# Altium schematic sheet Schematic Prints("All Documents",Physical) (continued)

## Library data of the parts
C1 C91 C93 CD0a CD0b CD0c CD0d CD0e CD0f CD0g CD0h CD0i CD0j CD0k CD20 CD31 CD32 = 100NF_0603_50V_X7R: 128-8255    Capacitor MLC-X7R_0603_100NF_10%_50V; C0603C104K5RAC; KEMET; RoHS=Yes; Signature_Author: Zagata; Value: 100nF; Category: Capacitors; Component Kind: Standard; Library Name: CAP0603_X7R.SchLib; Simulation: CAP; Supplier 1: Farnell; Supplier Part Number 1: 1288255; Signature_Date_Created: 2013-08-27; Signature_Date_LastModified: 2013-08-27
C90 C92 CD0 CD30 = 10UF_1206_25V_X7R: 209-4044    C_MLC-X7R_1206_10UF_10%_25V; 12063C106KAT2A; AVX; RoHS=Yes; Component Kind: Standard; Library Name: CAP1206_X7R.SchLib; Simulation: CAP; Supplier 1: Farnell; Supplier Part Number 1: 2094044
CF1-CF4 = 22PF_0603_50V_NP0: 141-4622    Capacitor MLC-NPO_0603_22PF_5%_50V; C0603C220J5GAC; KEMET; RoHS=Yes; Manufacturer Part Number: C0603C220J5GACTU; Capacitance: 22pF; Dielectric: C0G; Dielectric Material: Ceramic; Temperature Coefficient: 30ppm/°C; Tolerance: 5%; Voltage: 50V; Case Code (Imperial): 0603; Case Code (Metric): 1608; Case/Package: 0603; Component Kind: Standard; ComponentLink1Description: https://datasheet.ciiva.com/18192/getdatasheetpartid-385505-18192854.pdf?src-supplier=Verical; ComponentLink1URL: https://datasheet.ciiva.com/18192/getdatasheetpartid-385505-18192854.pdf?src-supplier=Verical; ComponentLink2Description: https://datasheet.ciiva.com/14586/70095383-14586058.pdf?src-supplier=Allied+Electronics; ComponentLink2URL: https://datasheet.ciiva.com/14586/70095383-14586058.pdf?src-supplier=Allied+Electronics; ComponentLink3Description: https://datasheet.ciiva.com/7824/f9080b-0402-series-c0g-7824012.pdf?src-supplier=Element14; ComponentLink3URL: https://datasheet.ciiva.com/7824/f9080b-0402-series-c0g-7824012.pdf?src-supplier=Element14; ComponentLink4Description: https://datasheet.ciiva.com/14599/70095383-14599853.pdf?src-supplier=Allied+Electronics; ComponentLink4URL: https://datasheet.ciiva.com/14599/70095383-14599853.pdf?src-supplier=Allied+Electronics; Contact Material: Tin; Depth: 0.8mm; Height: 0.8mm; Lead Free: Lead Free; Length: 1.6mm; Library Name: CAP0603_NPO.SchLib; Manufacturer: KEMET; Max Operating Temperature: 125°C; Min Operating Temperature: -55°C; Mount: Surface Mount,PCB; Number of Pins: 2; Package Quantity: 1; Packaging: Tape and Reel; Pricing 2: 1=0.17000, 10=0.12100, 100=0.05670, 500=0.03976, 1000=0.03291 (USD); Radiation Hardening: No; REACH SVHC: No SVHC; RoHS: Yes; Stock 1: 116489; Stock 2: 1090446; Supplier 1: Farnell; Supplier 2: Digi-Key; Supplier Part Number 1: 1414622; Supplier Part Number 2: 399-1053-1-ND; Termination: SMD/SMT; Thickness: 0.034inch; Voltage Rating: 50V; Voltage Rating (DC): 50V; Weight: 7.1E-05oz; Width: 0.8mm
CON_USB = USBmicro-B_2173157-3: 253-2426    2173157-3; Micro USB-B, RCP 5 POS 0.65mm Solder RA SMD 5 Terminal 1 Port T/R; TE; Manufacturer: TE Connectivity; Centerline_Pitch: .65 mm[.025 in]; Circuit Application: Power; Connector System: Cable-to-Board; Connector Type: Receptacle; Contact Current Rating: 1.8A; Gender: Female; Number of Ports: 1; Number of Positions: 5; Pitch: 0.025inch; Plating: Nickel,Tin; Product_Type: Connector; Voltage Rating: 30V; Component Kind: Standard; ComponentLink1Description: https://www.te.com/commerce/DocumentDelivery/DDEController?Action=srchrtrv&DocNm=2173157&DocType=Customer+Drawing&DocLang=English&src-supplier=Digi-Key; ComponentLink1URL: https://www.te.com/commerce/DocumentDelivery/DDEController?Action=srchrtrv&DocNm=2173157&DocType=Customer+Drawing&DocLang=English&src-supplier=Digi-Key; ComponentLink2Description: http://www.te.com/catalog/pn/en/2173157-3?RQPN=2173157-3&src-supplier=Avnet; ComponentLink2URL: http://www.te.com/catalog/pn/en/2173157-3?RQPN=2173157-3&src-supplier=Avnet; ComponentLink3Description: http://www.te.com/commerce/DocumentDelivery/DDEController?Action=srchrtrv&DocNm=2173157&DocType=Customer+Drawing&DocLang=English&src-supplier=Digi-Key; ComponentLink3URL: http://www.te.com/commerce/DocumentDelivery/DDEController?Action=srchrtrv&DocNm=2173157&DocType=Customer+Drawing&DocLang=English&src-supplier=Digi-Key; Contact Material: Copper Alloy; Contact Plating: Gold; Current Rating: 1.8A; Digi-Key_Part_Number: A124356CT-ND; Digi-Key_Purchase_URL: https://www.digikey.com/product-detail/en/te-connectivity-amp-connectors/2173157-3/A124356CT-ND/6026114?utm_source=snapeda&utm_medium=aggregator&utm_campaign=symbol; ELV: Compliant; EU_RoHS_Compliance: Compliant; Housing Color: Black; Housing Material: Thermoplastic; Lead Free: Lead Free; Length: 8.2mm; Library Name: CONNECTOR_USB.SchLib; Manufacturer Part Number: 2173157-3; Max Operating Temperature: 85°C; MF: TE Connectivity; Min Operating Temperature: -30°C; Mount: Surface Mount; MP: 2173157-3; Number_of_Positions: 5; Orientation: Right Angle; Package: None; Package Quantity: 1000; Packaging: Tape and Reel; Pricing 2: 1=3.39000, 10=3.25400, 25=2.98280, 50=2.84700, 100=2.71150, 250=2.37256, 500=2.30478 (USD); RoHS: Yes; SnapEDA_Link: https://www.snapeda.com/parts/2173157-3/TE%20Connectivity%20AMP%20Connectors/view-part/?ref=snap; Stock 1: 1167; Stock 2: 4317; Supplier 1: Farnell; Supplier 2: Digi-Key; Supplier Part Number 1: 2532426; Supplier Part Number 2: A124356CT-ND; TE_purchase_URL: https://www.te.com/usa-en/product-2173157-3.html?te_bu=Cor&te_type=disp&te_campaign=seda_glo_cor-seda-global-disp-prtnr-fy19-seda-model-bom-cta_sma-317_1&elqCampaignId=32493; Termination: SMD/SMT
CX1 CX2 = 22PF_0603_50V_NP0: 141-4622    Capacitor MLC-NPO_0603_22PF_5%_50V; C0603C220J5GAC; KEMET; RoHS=Yes; Capacitance: 22pF; Dielectric: C0G; Dielectric Material: Ceramic; Temperature Coefficient: 30ppm/°C; Tolerance: 5%; Voltage: 50V; Case Code (Imperial): 0603; Case Code (Metric): 1608; Case/Package: 0603; Component Kind: Standard; ComponentLink1Description: https://datasheet.ciiva.com/18192/getdatasheetpartid-385505-18192854.pdf?src-supplier=Verical; ComponentLink1URL: https://datasheet.ciiva.com/18192/getdatasheetpartid-385505-18192854.pdf?src-supplier=Verical; ComponentLink2Description: https://datasheet.ciiva.com/14586/70095383-14586058.pdf?src-supplier=Allied+Electronics; ComponentLink2URL: https://datasheet.ciiva.com/14586/70095383-14586058.pdf?src-supplier=Allied+Electronics; ComponentLink3Description: https://datasheet.ciiva.com/7824/f9080b-0402-series-c0g-7824012.pdf?src-supplier=Element14; ComponentLink3URL: https://datasheet.ciiva.com/7824/f9080b-0402-series-c0g-7824012.pdf?src-supplier=Element14; ComponentLink4Description: https://datasheet.ciiva.com/14599/70095383-14599853.pdf?src-supplier=Allied+Electronics; ComponentLink4URL: https://datasheet.ciiva.com/14599/70095383-14599853.pdf?src-supplier=Allied+Electronics; Contact Material: Tin; Depth: 0.8mm; Height: 0.8mm; Lead Free: Lead Free; Length: 1.6mm; Library Name: CAP0603_NPO.SchLib; Manufacturer: KEMET; Max Operating Temperature: 125°C; Min Operating Temperature: -55°C; Mount: Surface Mount,PCB; Number of Pins: 2; Package Quantity: 1; Packaging: Tape and Reel; Pricing 2: 1=0.17000, 10=0.12100, 100=0.05670, 500=0.03976, 1000=0.03291 (USD); Radiation Hardening: No; REACH SVHC: No SVHC; RoHS: Yes; Stock 1: 116489; Stock 2: 1090446; Supplier 1: Farnell; Supplier 2: Digi-Key; Supplier Part Number 1: 1414622; Supplier Part Number 2: 399-1053-1-ND; Termination: SMD/SMT; Thickness: 0.034inch; Voltage Rating: 50V; Voltage Rating (DC): 50V; Weight: 7.1E-05oz; Width: 0.8mm
D1 = HSMD-C191: 105-8359    LED DIODE ORANGE 20mA, 0603; HSMD-C191; AVAGO TECHNOLOGIES; RoHS=Yes; Component Kind: Standard; Library Name: DIODES_LED_SMD.SchLib; Simulation: LED2; Supplier 1: Farnell; Supplier Part Number 1: 1058359
D2 = HSMG-C191: 855-4617    LED DIODE GREEN 20mA, 0603; HSMG-C191; AVAGO TECHNOLOGIES; RoHS=Yes; Component Kind: Standard; Library Name: DIODES_LED_SMD.SchLib; Simulation: LED2; Supplier 1: Farnell; Supplier Part Number 1: 8554617
D9 = MBRS140T3G: 955-7237    DIODE SCHOTTKY 40V/1A, DO214AA; MBRS140T3G; ONSEMI; RoHS=Yes; Component Kind: Standard; Library Name: DIODES_SCHOTTKY.SchLib; Supplier 1: Farnell; Supplier 2: Digi-Key; Supplier Part Number 1: 9557237; Supplier Part Number 2: MBRS140T3GOSCT-ND
F1 F31 F32 = FILTER_ NFE31PT222Z1E9L: 952-8172     NFE31PT222Z1E9L ; FILTER, POWER LINE, 2200pF, 25V, 6A; 1206; Murata; 0_Signature: Status: APROVED, Author: I. Zagata, Created: 2019-09-19, Last Modified: 2019-09-19; 1_Category: Inductors; 2_Typ: Filter, EMI; Dielectric Material: Ceramic; ESD Protection: No; Insulation Resistance: 1GR; Number of Channels: 1; Tolerance: 50%; Case Code (Imperial): 1206; Case Code (Metric): 3216; Case/Package: 1206; Component Kind: Standard; ComponentLink1Description: https://datasheet.ciiva.com/34302/1871107-34302922.pdf?src-supplier=Jameco; ComponentLink1URL: https://datasheet.ciiva.com/34302/1871107-34302922.pdf?src-supplier=Jameco; ComponentLink2Description: https://datasheet.ciiva.com/11795/0900766b80032ea2-11795504.pdf?src-supplier=RSComponents; ComponentLink2URL: https://datasheet.ciiva.com/11795/0900766b80032ea2-11795504.pdf?src-supplier=RSComponents; ComponentLink3Description: https://datasheet.ciiva.com/22711/1756155-22711313.pdf?src-supplier=Element14; ComponentLink3URL: https://datasheet.ciiva.com/22711/1756155-22711313.pdf?src-supplier=Element14; ComponentLink4Description: https://datasheet.ciiva.com/26793/nfe31pt222z1e9l-26793392.pdf?src-supplier=IIIC; ComponentLink4URL: https://datasheet.ciiva.com/26793/nfe31pt222z1e9l-26793392.pdf?src-supplier=IIIC; ComponentLink5Description: https://datasheet.ciiva.com/1469/1756155-1469035.pdf?src-supplier=Element14; ComponentLink5URL: https://datasheet.ciiva.com/1469/1756155-1469035.pdf?src-supplier=Element14; ComponentLink6Description: https://datasheet.ciiva.com/1469/10397-1469036.pdf?src-supplier=Element14; ComponentLink6URL: https://datasheet.ciiva.com/1469/10397-1469036.pdf?src-supplier=Element14; ComponentLink7Description: https://datasheet.ciiva.com/1016/l0112s0113nfe31p-1016430.pdf?src-supplier=Digi-Key; ComponentLink7URL: https://datasheet.ciiva.com/1016/l0112s0113nfe31p-1016430.pdf?src-supplier=Digi-Key; ComponentLink8Description: https://datasheet.ciiva.com/16387/l0112s0113nfe31p-16387318.pdf?src-supplier=Future+Electronics; ComponentLink8URL: https://datasheet.ciiva.com/16387/l0112s0113nfe31p-16387318.pdf?src-supplier=Future+Electronics; ComponentLink9Description: https://datasheet.ciiva.com/1016/l0112s0113nfe31p-1016428.pdf?src-supplier=Digi-Key; ComponentLink9URL: https://datasheet.ciiva.com/1016/l0112s0113nfe31p-1016428.pdf?src-supplier=Digi-Key; ComponentLink10Description: https://datasheet.ciiva.com/1016/l0112s0113nfe31p-1016429.pdf?src-supplier=Digi-Key; ComponentLink10URL: https://datasheet.ciiva.com/1016/l0112s0113nfe31p-1016429.pdf?src-supplier=Digi-Key; Current: 6A; Current Rating: 6A; Depth: 1.6mm; Height: 1.6mm; Lead Free: Lead Free; Length: 3.2mm; Library Name: INDUCTORS_SMD.SchLib; Manufacturer: Murata; Manufacturer Part Number: NFE31PT222Z1E9L; Max Current Rating: 6A; Max Operating Temperature: 85°C; Max Voltage Rating (DC): 25V; Min Operating Temperature: -40°C; Mount: Surface Mount,PCB; Number of Pins: 2; Package Quantity: 1; Packaging: Tape and Reel; Radiation Hardening: No; REACH SVHC: No SVHC; RoHS: Yes; Stock 1: 41431; Supplier 1: Farnell; Supplier Part Number 1: 9528172; Termination: Flat; Voltage Rating: 25V; Voltage Rating (DC): 25V; Width: 1.6mm
R1-R21 = 100R_1206_0.063W_5%: 923-4454    RESISTOR ARRAY 100R_1206_0.063W_5%; 235003510101; PHYCOMP; RoHS=Yes; Component Kind: Standard; Simulation: RESISTOR; Supplier 1: Farnell; Supplier Part Number 1: 9234454; Library Name: RESISTOR_PACK_ARV.SchLib
R01 R91 RK1 RK2 = 10K_0603_0.1W_5%: 923-3504    RESISTOR MF_0603_10KR_0.063W_5%_50V; 232270260103; PHYCOMP; RoHS=Yes; Component Kind: Standard; Library Name: Resistor0603_0.063W.SchLib; Simulation: RESISTOR; Supplier 1: Farnell; Supplier Part Number 1: 9233504
R02 = 2.2K_1206_0.063W_5%: 923-4535    RESISTOR ARRAY 2K2_1206_0.063W_5%; 235003510222; PHYCOMP; RoHS=Yes (repl. for 196-794); Component Kind: Standard; Simulation: RESISTOR; Library Name: RESISTOR_PACK_ARV.SchLib
R03 RL1 RL2 = 470R_0603_0.1W_5%: 923-3334    RESISTOR MF_0603_470R_0.1W_5%_50V; RC0603JR-07470RL; YAGEO; RoHS=Yes; Component Kind: Standard; Library Name: Resistor0603_0.063W.SchLib; Simulation: RESISTOR
R31 R32 = 2.0K_0603_0.063W_1%: 933-0763   RESISTOR MF_0603_2.0KR_0.063W_1%_50V;  MC0063W060312K; MULTICOMP; Component Kind: Standard; Library Name: Resistor0603_0.063W.SchLib; Simulation: RESISTOR
SW1 = SWDIP-2-SMD_MULTICOMP: 160-5473    MCDHN-02F-V; SWITCH, HALF-PITCH SMD SO, 2 ways; MULTICOMP; Component Kind: Standard; Library Name: MISC.SchLib; Supplier 1: Farnell; Supplier Part Number 1: 1605473
TP = TPAD: xxx-xxxx    TEST PAD - NO COMPONENT TO SOLDER; Signature_Author: Zagata; Category: Misc; Library Name: MISC.SchLib; Signature_Date_Created: 2013-08-27; Signature_Date_LastModified: 2013-08-27
U0 = PIC32MZ1024EFH100-E/PF_ONMCU-V2: DK:PIC32MZ1024EFH100-E/PF-ND    PIC32MZ1024EFH100-E/PF; MIPS32® M-Class Automotive, AEC-Q100, PIC® 32MZ Microcontroller IC 32-Bit 180MHz 1MB (1M x 8) FLASH; TQFP100 (14x14); Microchip; 0_Signature: Status: APROVED, Author: I. Zagata, Created: 2019-08-23, Last Modified: 2019-08-23; 1_Category: ICs; Case/Package: TQFP; Component Kind: Standard; Library Name: IC_MCUs.SchLib; Manufacturer: Microchip; Pricing 1: 1=12.07000, 25=11.06240, 100=10.02190 (USD); RoHS: Yes; Stock 1: 263; Supplier 1: Digi-Key; Supplier Part Number 1: PIC32MZ1024EFH100-E/PF-ND
U9 = MC33375ST-3.3T3G: 115-0444    REGULATOR 3.3V, 0.3A; MC33375ST-3.3T3G; ON SEMI; RoHS=Yes; Component Kind: Standard; Supplier 1: Farnell; Supplier 2: Digi-Key; Supplier Part Number 1: 2296053; Supplier Part Number 2: MC33375ST-3.3T3GOSCT-ND; Library Name: IC_VOLT_REG.SchLib
U20 = 7S14P5X_SC70: 146-7334    NC7S14P5X; Single Schmitt-Trigger Inverter; FAIRCHILD; RoHS=Yes; Repl for 126-2608; Manufacturer: ON Semiconductor / Fairchild; High Level Output Current: -2.6mA; Logic Function: Buffer,Inverter,Schmitt Trigger; Low Level Output Current: 2.6mA; Max Supply Voltage: 6V; Min Supply Voltage: 2V; Number of Circuits: 1; Propagation Delay: 17ns; Quiescent Current: 1uA; Schmitt Trigger Input: Yes; Turn-On Delay Time: 125ns; Case/Package: SC; Component Kind: Standard; ComponentLink1Description: https://datasheet.ciiva.com/4826/nc7s14-115813-4826946.pdf?src-supplier=Mouser; ComponentLink1URL: https://datasheet.ciiva.com/4826/nc7s14-115813-4826946.pdf?src-supplier=Mouser; ComponentLink2Description: https://datasheet.ciiva.com/34301/873784-34301634.pdf?src-supplier=Jameco; ComponentLink2URL: https://datasheet.ciiva.com/34301/873784-34301634.pdf?src-supplier=Jameco; ComponentLink3Description: https://datasheet.ciiva.com/15089/getdatasheetpartid-69776-15089145.pdf?src-supplier=Verical; ComponentLink3URL: https://datasheet.ciiva.com/15089/getdatasheetpartid-69776-15089145.pdf?src-supplier=Verical; ComponentLink4Description: https://datasheet.ciiva.com/25628/0900766b810e259d-25628669.pdf?src-supplier=RSComponents; ComponentLink4URL: https://datasheet.ciiva.com/25628/0900766b810e259d-25628669.pdf?src-supplier=RSComponents; ComponentLink5Description: https://datasheet.ciiva.com/1861/nc7s14-1861941.pdf?src-supplier=Digi-Key; ComponentLink5URL: https://datasheet.ciiva.com/1861/nc7s14-1861941.pdf?src-supplier=Digi-Key; ComponentLink6Description: https://datasheet.ciiva.com/8248/nc7s14-8248821.pdf?src-supplier=Avnet; ComponentLink6URL: https://datasheet.ciiva.com/8248/nc7s14-8248821.pdf?src-supplier=Avnet; ComponentLink7Description: https://datasheet.ciiva.com/1861/nc7s14-1861942.pdf?src-supplier=Digi-Key; ComponentLink7URL: https://datasheet.ciiva.com/1861/nc7s14-1861942.pdf?src-supplier=Digi-Key; ComponentLink8Description: https://datasheet.ciiva.com/1861/nc7s14-1861943.pdf?src-supplier=Digi-Key; ComponentLink8URL: https://datasheet.ciiva.com/1861/nc7s14-1861943.pdf?src-supplier=Digi-Key; ComponentLink9Description: https://datasheet.ciiva.com/16989/nc7s14-16989591.pdf?src-supplier=Future+Electronics; ComponentLink9URL: https://datasheet.ciiva.com/16989/nc7s14-16989591.pdf?src-supplier=Future+Electronics; ComponentLink10Description: https://datasheet.ciiva.com/26242/nc7s14-26242158.pdf?src-supplier=Avnet+Europe; ComponentLink10URL: https://datasheet.ciiva.com/26242/nc7s14-26242158.pdf?src-supplier=Avnet+Europe; ComponentLink11Description: https://datasheet.ciiva.com/48704/001253334-da-01-en-ic-inverter-hs-sch-nc7s14p5x-sc-70-5-fsc-48704727.pdf?src-supplier=Conrad; ComponentLink11URL: https://datasheet.ciiva.com/48704/001253334-da-01-en-ic-inverter-hs-sch-nc7s14p5x-sc-70-5-fsc-48704727.pdf?src-supplier=Conrad; Contact Plating: Tin,Matte; Height: 0.9mm; Lead Free: Lead Free; Length: 2mm; Library Name: IC_74xx.SchLib; Max Operating Temperature: 85°C; Min Operating Temperature: -40°C; Mount: Surface Mount; Number of Elements: 1; Number of Gates: 1; Number of Inputs: 1; Number of Pins: 5; Output Current: 2.6mA; Package Quantity: 3000; Packaging: Tape and Reel; Pricing 2: 1=0.33000, 10=0.24600, 100=0.13950, 500=0.09240, 1000=0.07084 (USD); Radiation Hardening: No; REACH SVHC: No SVHC; RoHS: Yes; Stock 1: 19135; Stock 2: 8563; Supplier 1: Farnell; Supplier 2: Digi-Key; Supplier Part Number 1: 1467334; Supplier Part Number 2: NC7S14P5XCT-ND; Weight: 23g; Width: 1.25mm
U31 U32 = AD5641BKSZ-500RL7: 237-6822    AD5641BKSZ; DAC, 14bit, SPI, 2.7 .. 5.5V; SC70-6; AD; Manufacturer: Analog Devices; 0_Signature: Status: APROVED, Author: I. Zagata, Created: 2019-08-29, Last Modified: 2019-08-29; 1_Category: ICs; Conversion Rate: 1.7MS/s; Differential Output: No; Integral Nonlinearity (INL): 4LSB; Interface: SPI,Serial; Max Power Dissipation: 550uW; Min Input Voltage: 2.7V; Nominal Supply Current: 75uA; Number of Bits: 14; Number of Channels: 1; Output Type: Voltage; Polarity: Unipolar,Bipolar; Power Dissipation: 5.5mW; Resolution: 14b; Settling Time: 6us; Slew Rate: 0.5V/µs; Case/Package: SC; Component Kind: Standard; ComponentLink1Description: https://datasheet.ciiva.com/6665/ad5641-6665824.pdf?src-supplier=Digi-Key; ComponentLink1URL: https://datasheet.ciiva.com/6665/ad5641-6665824.pdf?src-supplier=Digi-Key; ComponentLink2Description: https://datasheet.ciiva.com/1360/ad5641-1360625.pdf?src-supplier=Digi-Key; ComponentLink2URL: https://datasheet.ciiva.com/1360/ad5641-1360625.pdf?src-supplier=Digi-Key; ComponentLink3Description: https://datasheet.ciiva.com/8191/ad5641-8191978.pdf?src-supplier=Avnet; ComponentLink3URL: https://datasheet.ciiva.com/8191/ad5641-8191978.pdf?src-supplier=Avnet; ComponentLink4Description: https://datasheet.ciiva.com/16599/ad5641-16599067.pdf?src-supplier=Avnet+Europe; ComponentLink4URL: https://datasheet.ciiva.com/16599/ad5641-16599067.pdf?src-supplier=Avnet+Europe; ComponentLink5Description: https://datasheet.ciiva.com/6665/ad5641-6665822.pdf?src-supplier=Digi-Key; ComponentLink5URL: https://datasheet.ciiva.com/6665/ad5641-6665822.pdf?src-supplier=Digi-Key; ComponentLink6Description: https://datasheet.ciiva.com/28465/1563195-28465279.pdf?src-supplier=Element14; ComponentLink6URL: https://datasheet.ciiva.com/28465/1563195-28465279.pdf?src-supplier=Element14; Contact Plating: Gold; Height: 1mm; Lead Free: Contains Lead; Length: 2.2mm; Library Name: IC_Other.SchLib; Manufacturer Part Number: AD5641BKSZ-500RL7; Max Input Voltage: 5.5V; Max Operating Temperature: 125°C; Max Output Voltage: 5.5V; Max Supply Voltage: 5.5V; Min Operating Temperature: -40°C; Min Output Voltage: 2.7V; Min Supply Voltage: 2.7V; Number of Converters: 1; Number of D/A Converters: 1; Number of DAC Channels: 1; Number of Pins: 6; Package Quantity: 500; Packaging: Tape and Reel; Pricing 2: 1=5.65000, 10=5.07600, 25=4.79840, 100=4.15860, 250=3.94532 (USD); Radiation Hardening: No; REACH SVHC: No SVHC; RoHS: Yes; Sampling Rate: 1.7MS/s; Stock 1: 0; Stock 2: 483; Supplier 1: Farnell; Supplier 2: Digi-Key; Supplier Part Number 1: 2376822; Supplier Part Number 2: AD5641BKSZ-500RL7CT-ND; Width: 1.35mm
X0 = EUROQUARZ_20.000MHZ/12PF: 164-0933    CRYSTAL, SMD, 20.000MHZ MJ/30/30/40/12PF; EUROQUARZ RoHS=Yes; Component Kind: Standard; Library Name: CRYSTALS.SchLib; Supplier 1: Farnell; Supplier Part Number 1: 1640933
ZD91 = SMAJ5.0A: 955-0941    DIODE TVS SMA 400W Vb=6.4V; SMAJ5.0A; VISHAY GENERAL SEMICONDUCTOR; RoHS=Yes; Manufacturer: Vishay Semiconductors; Breakdown Voltage: 6.4V; Clamping Voltage: 9.2V; Direction: Unidirectional; Element Configuration: Single; Leakage Current: 800uA; Max Breakdown Voltage: 7.07V; Max Forward Surge Current (Ifsm): 40A; Max Surge Current: 43.5A; Number of Channels: 1; Operating Supply Voltage: 5V; Test Current: 10mA; Working Voltage: 3.5V; Case/Package: SMA; Component Kind: Standard; ComponentLink1Description: https://datasheet.ciiva.com/26322/1734589-26322359.pdf?src-supplier=Element14; ComponentLink1URL: https://datasheet.ciiva.com/26322/1734589-26322359.pdf?src-supplier=Element14; ComponentLink2Description: https://datasheet.ciiva.com/28409/548547-28409637.pdf?src-supplier=Element14; ComponentLink2URL: https://datasheet.ciiva.com/28409/548547-28409637.pdf?src-supplier=Element14; ComponentLink3Description: https://datasheet.ciiva.com/26322/1734589-26322358.pdf?src-supplier=Element14; ComponentLink3URL: https://datasheet.ciiva.com/26322/1734589-26322358.pdf?src-supplier=Element14; ComponentLink4Description: https://datasheet.ciiva.com/16199/smaj50a-16199260.pdf?src-supplier=Future+Electronics; ComponentLink4URL: https://datasheet.ciiva.com/16199/smaj50a-16199260.pdf?src-supplier=Future+Electronics; ComponentLink5Description: https://datasheet.ciiva.com/26322/548547-26322556.pdf?src-supplier=Element14; ComponentLink5URL: https://datasheet.ciiva.com/26322/548547-26322556.pdf?src-supplier=Element14; Contact Plating: Tin; Height: 2.29mm; Lead Free: Lead Free; Length: 4.5mm; Max Operating Temperature: 150°C; Max Power Dissipation: 400W; Max Reverse Leakage Current: 800uA; Min Breakdown Voltage: 6.4V; Min Operating Temperature: -55°C; Number of Elements: 1; Number of Pins: 2; Package Quantity: 1800; Packaging: Tape and Reel; Peak Pulse Current: 43.5A; Peak Pulse Power: 400W; Polarity: Unidirectional; Power Dissipation: 400W; Pricing 2: 1=0.42000, 10=0.31500, 25=0.28400, 100=0.19610, 250=0.16512, 500=0.13416 (USD); Radiation Hardening: No; REACH SVHC: Unknown; Reverse Breakdown Voltage: 6.4V; Reverse Standoff Voltage: 5V; RoHS: Yes; Stock 1: 33405; Stock 2: 146734; Supplier 1: Farnell; Supplier 2: Digi-Key; Supplier Part Number 1: 9550941; Supplier Part Number 2: SMAJ5.0A-E3/61GICT-ND; Termination: SMD/SMT; Voltage Rating (DC): 5V; Width: 2.79mm; Library Name: DIODES_TRANSIL.SchLib
ZD92 = SZMMSZ5V1T1G_5V1_SOD123: 284-4870    ZENER DIODE 5.1V/500mW/10mA/150°C; SZMMSZ5V1T1G; SOD123; ONSEMI; AEC-Q101; Manufacturer: ON Semiconductor; Element Configuration: Single; ESD Protection: Yes; Impedance: 60R; Max Power Dissipation: 500mW; Max Reverse Leakage Current: 2uA; Test Current: 5mA; Tolerance: 5%; Zener Voltage: 5.1V; Case/Package: SOD-123-2; Component Kind: Standard; ComponentLink1Description: https://datasheet.ciiva.com/10638/mmsz2v4t1-d-10638229.pdf?src-supplier=Avnet; ComponentLink1URL: https://datasheet.ciiva.com/10638/mmsz2v4t1-d-10638229.pdf?src-supplier=Avnet; ComponentLink2Description: https://datasheet.ciiva.com/26092/mmsz2v4t1-d-26092581.pdf?src-supplier=Avnet+Europe; ComponentLink2URL: https://datasheet.ciiva.com/26092/mmsz2v4t1-d-26092581.pdf?src-supplier=Avnet+Europe; ComponentLink3Description: https://datasheet.ciiva.com/900/mmsz2v4t1-d-900314.pdf?src-supplier=Digi-Key; ComponentLink3URL: https://datasheet.ciiva.com/900/mmsz2v4t1-d-900314.pdf?src-supplier=Digi-Key; Contact Plating: Tin,Matte; Lead Free: Lead Free; Library Name: DIODES_ZENER.SchLib; Max Operating Temperature: 150°C; Min Operating Temperature: -55°C; Number of Pins: 2; Package Quantity: 3000; Packaging: Tape and Reel; Power Dissipation: 500mW; Pricing 3: 1=0.38000, 10=0.28600, 100=0.16190, 500=0.10722, 1000=0.08220 (USD); Radiation Hardening: No; RoHS: Yes; Stock 2: 7115; Stock 3: 7818; Supplier 1: Farnell; Supplier 2: Farnell; Supplier 3: Digi-Key; Supplier Part Number 1: 1431202; Supplier Part Number 2: 2844870; Supplier Part Number 3: SZMMSZ5V1T1GOSCT-ND; Voltage Tolerance: 5%
